# Altium schematic sheet circuit_BLDCControl.SchDoc
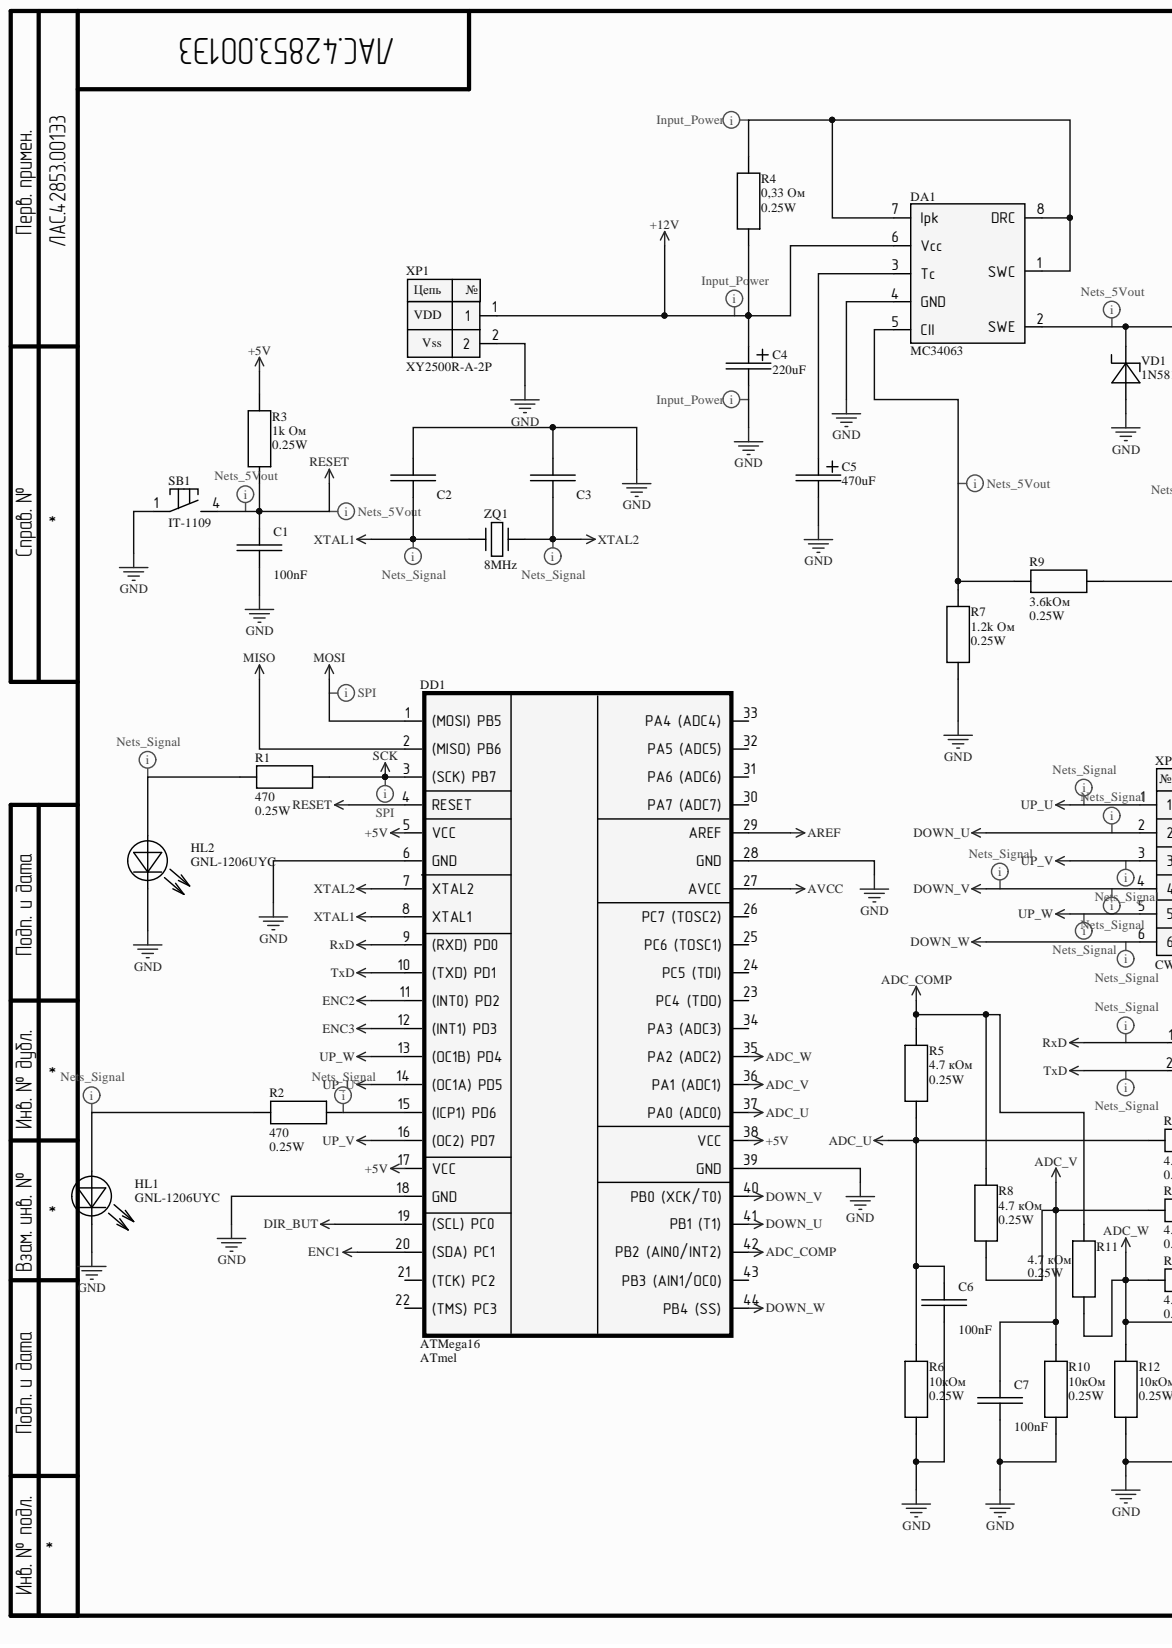
[diagram p0: circuit_BLDCControl.SchDoc, left]
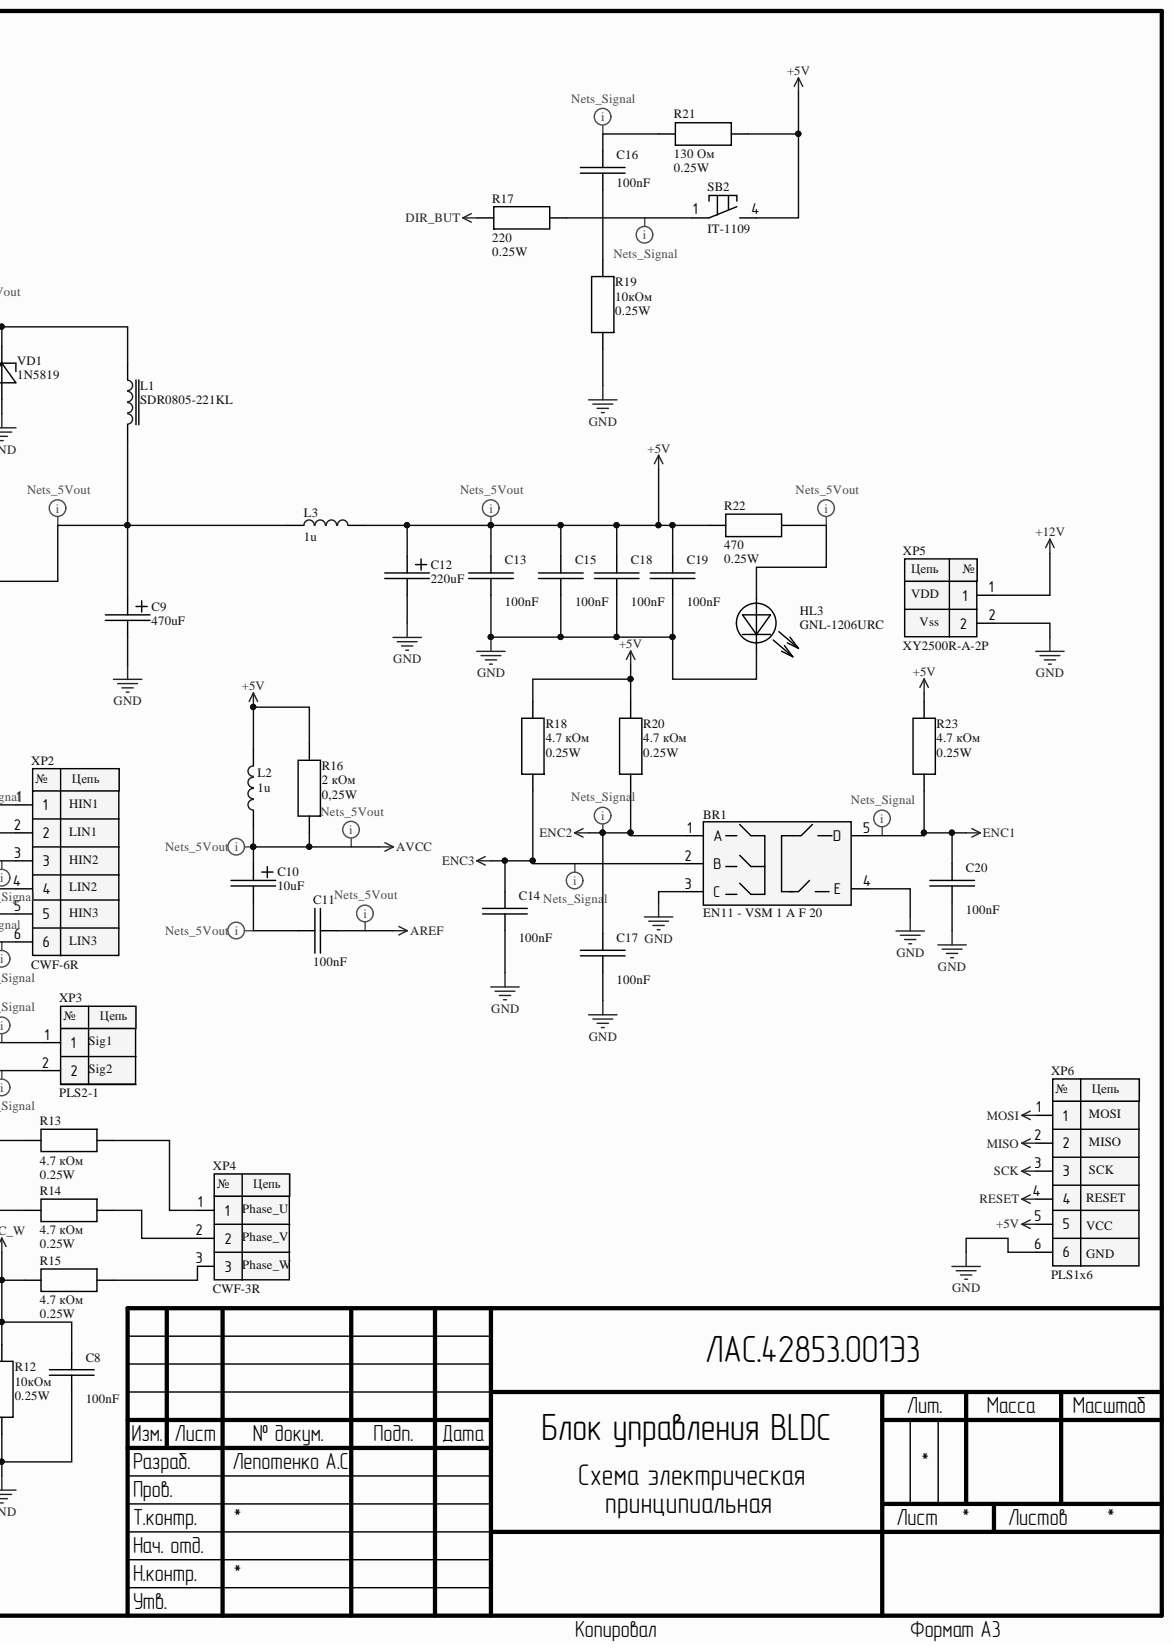
[diagram p1: circuit_BLDCControl.SchDoc, right]

## Parts
BR1  EN11 - VSM 1 A F 20  [EN11-HSM]
C1  100nF  [CAP1206]
C2  22pF  [CAP1206]  (value not drawn)
C3  22pF  [CAP1206]  (value not drawn)
C4  220uF  [CAPAE120103X105MTypeG]
C5  470uF  [CAPAE120103X105MTypeG]
C6  100nF  [CAP1206]
C7  100nF  [CAP1206]
C8  100nF  [CAP1206]
C9  470uF  [CAPAE120103X105MTypeG]
C10  10uF  [Type D]
C11  100nF  [CAP1206]
C12  220uF  [CAPAE120103X105MTypeG]
C13  100nF  [CAP1206]
C14  100nF  [CAP1206]
C15  100nF  [CAP1206]
C16  100nF  [CAP1206]
C17  100nF  [CAP1206]
C18  100nF  [CAP1206]
C19  100nF  [CAP1206]
C20  100nF  [CAP1206]
DA1  MC34063  [SO-8]
DD1  ATMega16  Manufacturer: ATmel  [TQFP80P1200X1200X120-44N]
HL1  GNL-1206UYC  [LED1206]
HL2  GNL-1206UYC  [LED1206]
HL3  GNL-1206URC  [LED1206]
L1  SDR0805-221KL  [SDR0805]
L2  1u  [LQG15HN15NJ]
L3  1u  [LQG15HN15NJ]
R1  470  Power: 0.25W  [1206]
R2  470  Power: 0.25W  [1206]
R3  1k Ом  Power: 0.25W  [1206]
R4  0,33 Ом  Power: 0.25W  [1206]
R5  4.7 кОм  Power: 0.25W  [1206]
R6  10кОм  Power: 0.25W  [1206]
R7  1.2k Ом  Power: 0.25W  [1206]
R8  4.7 кОм  Power: 0.25W  [1206]
R9  3.6kОм  Power: 0.25W  [1206]
R10  10кОм  Power: 0.25W  [1206]
R11  4.7 кОм  Power: 0.25W  [1206]
R12  10кОм  Power: 0.25W  [1206]
R13  4.7 кОм  [1206]
R14  4.7 кОм  Power: 0.25W  [1206]
R15  4.7 кОм  Power: 0.25W  [1206]
R16  2 кОм  Power: 0,25W  [1206]
R17  220  Power: 0.25W  [1206]
R18  4.7 кОм  Power: 0.25W  [1206]
R19  10кОм  Power: 0.25W  [1206]
R20  4.7 кОм  Power: 0.25W  [1206]
R21  130 Ом  [1206]
R22  470  Power: 0.25W  [1206]
R23  4.7 кОм  Power: 0.25W  [1206]
SB1  IT-1109  [KLS2]
SB2  IT-1109  [KLS2]
VD1  1N5819  [DO-41-V]
XP1  XY2500R-A-2P  [KLS2-EDR-500-2P]
XP2  CWF-6R  [CWF-6R]
XP3  PLS2-1  [PLS-2-1]
XP4  CWF-3R  [CWF-3R]
XP5  XY2500R-A-2P  [KLS2-EDR-500-2P]
XP6  PLS1x6  [PLS1x6]
ZQ1  8MHz  [HC49-S]

## Nets
+5V: C12.1 C13.1 C15.1 C18.1 C19.1 DD1.5(VCC) DD1.17(VCC) DD1.38(VCC) L2.2 L3.2 R3.2 R16.2 R18.2 R20.2 R21.2 R22.2 R23.2 SB2.4 XP6.5
+12V: C4.1 DA1.6(Vcc) R4.1 XP1.1 XP5.1
ADC_COMP: DD1.42((AIN0/INT2)) R5.1 R8.1 R11.1
ADC_U: C6.1 DD1.37((ADC0)) R5.2 R6.1 R13.2
ADC_V: C7.1 DD1.36((ADC1)) R8.2 R10.1 R14.2
ADC_W: C8.1 DD1.35((ADC2)) R11.2 R12.1 R15.2
AREF: C11.2 DD1.29(AREF)
AVCC: C10.1 DD1.27(AVCC) L2.1 R16.1
DIR_BUT: DD1.19((SCL)) R17.1
DOWN_U: DD1.41((T1)) XP2.2
DOWN_V: DD1.40((XCK/T0)) XP2.4
DOWN_W: DD1.44((SS)) XP2.6
ENC1: BR1.5(D) C20.1 DD1.20((SDA)) R23.1
ENC2: BR1.1 C17.1 DD1.11((INT0)) R20.1
ENC3: BR1.2(B) C14.1 DD1.12((INT1)) R18.1
GND: BR1.3(C) BR1.4(E) C1.2 C2.1 C3.1 C4.2 C5.2 C6.2 C7.2 C8.2 C9.2 C12.2 C13.2 C14.2 C15.2 C17.2 C18.2 C19.2 C20.2 DA1.4(GND) DD1.6(GND) DD1.18(GND) DD1.28(GND) DD1.39(GND) HL1.2 HL2.2 HL3.2 R6.2 R7.1 R10.2 R12.2 R19.1 SB1.1 VD1.1 XP1.2 XP5.2 XP6.6
MISO: DD1.2((MISO)) XP6.2
MOSI: DD1.1((MOSI)) XP6.1
RESET: C1.1 DD1.4(RESET) R3.1 SB1.4 XP6.4
RxD: DD1.9((RXD)) XP3.1
SCK: DD1.3((SCK)) R1.1 XP6.3
TxD: DD1.10((TXD)) XP3.2
UP_U: DD1.14((OC1A)) XP2.1
UP_V: DD1.16((OC2)) XP2.3
UP_W: DD1.13((OC1B)) XP2.5
XTAL1: C2.2 DD1.8(XTAL1) ZQ1.1
XTAL2: C3.2 DD1.7(XTAL2) ZQ1.3
NetC5_1: C5.1 DA1.3(Tc)
NetC9_1: C9.1 L1.2 L3.1 R9.2
NetC10_2: C10.2 C11.1
NetC16_1: C16.1 R21.1
NetC16_2: C16.2 R19.2
NetDA1_1: DA1.1(SWC) DA1.7(Ipk) DA1.8(DRC) R4.2
NetDA1_2: DA1.2(SWE) L1.1 VD1.2
NetDA1_5: DA1.5(CII) R7.2 R9.1
NetDD1_15: DD1.15((ICP1)) R2.1
NetHL1_1: HL1.1 R2.2
NetHL2_1: HL2.1 R1.2
NetHL3_1: HL3.1 R22.1
NetR13_1: R13.1 XP4.1
NetR14_1: R14.1 XP4.2
NetR15_1: R15.1 XP4.3
NetR17_2: R17.2 SB2.1

Not connected: DD1 21((TCK)) 22((TMS)) 23((TDO)) 24((TDI)) 25((TOSC1)) 26((TOSC2)) 30((ADC7)) 31((ADC6)) 32((ADC5)) 33((ADC4)) 34((ADC3)) 43((AIN1/OC0))

## Library data of the parts
BR1 = Encoder: Manufacturer: TTelectronics; Component Kind: Standard; Documentation: http://belchip.by/sitedocs/18676.pdf; Package: CWF-3R; Part Number: EN11 - VSM 1 A F 20; Price: 0.05; Quantity: 3; ShoppingPlace: http://belchip.by/product/?selected_product=17773; Library Name: SchlibUGO.SchLib
C1 C6-C8 C11 C13-C20 = Capasitor: Manufacturer: Samsung; Part Number: CL31B104KBCNNNC; Voltage: 50V; Component Kind: Standard; Package: 1206; Price: 0,035; Quantity: 4; ShoppingPlace: http://belchip.by/product/?selected_product=31093; Value: 100nF; Library Name: SchlibUGO.SchLib
C2 C3 = Capasitor: Manufacturer: Samsung; Part Number: NPO-50V; Voltage: 50V; Component Kind: Standard; Package: 1206; Price: 0.025; Quantity: 2; ShoppingPlace: http://belchip.by/product/?selected_product=00259; Value: 22pF; Library Name: SchlibUGO.SchLib
C4 C12 = Capasitor Electrolit: Manufacturer: Китай; Part Number: 220uF 35V 10x10; Power: 10V; Component Kind: Standard; Documentation: http://belchip.by/product/?selected_product=32976; Package: 10x10; Price: 1; Quantity: 3; ShoppingPlace: http://belchip.by/product/?selected_product=32976; Value: 220uF; Library Name: SchlibUGO.SchLib
C5 C9 = Capasitor Electrolit: Manufacturer: EPCOS; Part Number: B41121A3477M000; Power: 25V; Component Kind: Standard; Documentation: https://static.chipdip.ru/lib/051/DOC000051935.pdf; Package: 8x10; Price: 2; ShoppingPlace: https://www.ru-chipdip.by/product/b41121a3477m000; Value: 470uF; Library Name: SchlibUGO.SchLib
C10 = Capasitor Electrolit: Manufacturer: Vishay; Part Number: D 10uF 25V 20; Power: 25V; Component Kind: Standard; Package: TypeD; Price: 0,23; ShoppingPlace: http://belchip.by/product/?selected_product=00096; Value: 10uF; Library Name: SchlibUGO.SchLib
DA1 = MC34063: Manufacturer: ON Semiconductor; Component Kind: Standard; Package: SO-8; part Number: MC34063; Price: 0,3; Quantity: 1; ShoppingPlace: http://belchip.by/product/?selected_product=28823; Library Name: SchlibUGO.SchLib
DD1 = ATMega 16: Component Kind: Standard; Documentation: http://belchip.by/sitedocs/00002095.pdf; Package: TQFP44; Part Number: ATMega16; Price: 5,2; Quantity: 1; ShoppingPlace: http://belchip.by/product/?selected_product=02095; Value: ATMega16; Library Name: SchlibUGO.SchLib
HL1 HL2 = LED: Manufacturer: G-NOR; Value: YELLOW; Component Kind: Standard; Package: 1206; Part Number: GNL-1206UYC; Price: 0.06; Quantity: 6; ShoppingPlace: http://belchip.by/product/?selected_product=00949; Library Name: SchlibUGO.SchLib
HL3 = LED: Manufacturer: G-NOR; Value: RED; Component Kind: Standard; Package: 1206; Part Number: GNL-1206URC; Price: 0.06; Quantity: 6; ShoppingPlace: http://belchip.by/product/?selected_product=00953; Library Name: SchlibUGO.SchLib
L1 = Induc_SD1105: Manufacturer: BOURNS; Value: 220uH; Component Kind: Standard; Documentation: http://docs-europe.electrocomponents.com/webdocs/150d/0900766b8150ddf1.pdf; Package: SDR0805; Part Number: SDR0805-221KL; Price: 1; Quantity: 1; ShoppingPlace: https://www.ru-chipdip.by/product/sdr1105-201k; Library Name: SchlibUGO.SchLib
L2 L3 = Inductor: Manufacturer: Bourns; Part Number: CM453232-1R0KL; Component Kind: Standard; Package: 1812; Price: 0.225; Quantity: 2; ShoppingPlace: https://www.ru-chipdip.by/product/cm453232-1r0kl; Value: 1u; Library Name: SchlibUGO.SchLib
R1 R2 R22 = Resistor: Manufacturer: YAGEO; Part Number: RC1206JR-07470RL; Quntity: 3; Component Kind: Standard; Package: 1206; Price: 0.15; ShoppingPlace: http://belchip.by/product/?selected_product=32449; Value: 470; Library Name: SchlibUGO.SchLib
R3 = Resistor: Manufacturer: Royal Ohm; Part Number: RC1206FR-071KL; Quntity: 1; Component Kind: Standard; Package: 1206; Price: 0,02; ShoppingPlace: http://belchip.by/product/?selected_product=19295; Value: 1k Ом; Library Name: SchlibUGO.SchLib
R4 = Resistor: Manufacturer: Royal Ohm; Part Number: RC1206FR-12060R33; Quntity: 1; Component Kind: Standard; Documentation: http://belchip.by/sitedocs/18520.pdf; Package: 1206; Price: 0,5; ShoppingPlace: http://belchip.by/product/?selected_product=32979; Value: 0,33 Ом; Library Name: SchlibUGO.SchLib
R5 R6 R8 R10-R12 R14 R15 R18 R20 R23 = Resistor: Manufacturer: YAGEO; Part Number: RC1206JR-074K7L; Quntity: 4; Component Kind: Standard; Package: 1206; Price: 0,15; ShoppingPlace: http://belchip.by/product/?selected_product=01399; Library Name: SchlibUGO.SchLib
  R5 R8 R11 R14 R15 R18 R20 R23: Value: 4.7 кОм
  R6 R10 R12: Value: 10кОм
R7 = Resistor: Manufacturer: РФ; Part Number: 1206 1.2K; Quntity: 1; Component Kind: Standard; Package: 1206; Price: 0,15; ShoppingPlace: http://belchip.by/product/?selected_product=01386; Value: 1.2k Ом; Library Name: SchlibUGO.SchLib
R9 = Resistor: Manufacturer: РФ; Part Number: 1206 3.6K; Quntity: 1; Component Kind: Standard; Package: 1206; Price: 1; ShoppingPlace: http://belchip.by/product/?selected_product=01396; Value: 3.6kОм; Library Name: SchlibUGO.SchLib
R13 = Resistor: Manufacturer: YAGEO; Part Number: RC1206JR-074K7L; Power: 0.25W; Quntity: 4; Component Kind: Standard; Package: 1206; Price: 0,15; ShoppingPlace: http://belchip.by/product/?selected_product=01399; Value: 4.7 кОм; Library Name: SchlibUGO.SchLib
R16 = Resistor: Manufacturer: РФ; Part Number: SMD 1206 2K; Quntity: 1; Component Kind: Standard; Package: 1206; Price: 0,15; ShoppingPlace: http://belchip.by/product/?selected_product=01391; Value: 2 кОм; Library Name: SchlibUGO.SchLib
R17 = Resistor: Manufacturer: Royal Ohm; Part Number: HP06-220R5; Quntity: 1; Component Kind: Standard; Package: 1206; Price: 0,02; ShoppingPlace: http://belchip.by/product/?selected_product=06325; Value: 220; Library Name: SchlibUGO.SchLib
R19 = Resistor: Manufacturer: YAGEO; Part Number: RC1206JR-0710KL; Quntity: 1; Component Kind: Standard; Package: 1206; Price: 0.15; ShoppingPlace: http://belchip.by/product/?selected_product=31101; Value: 10кОм; Library Name: SchlibUGO.SchLib
R21 = Resistor: Manufacturer: RF; Part Number: 130R; Power: 0.25W; Quntity: 1; Component Kind: Standard; Package: 1206; Price: 0,15; ShoppingPlace: http://belchip.by/product/?selected_product=01363; Value: 130 Ом; Library Name: SchlibUGO.SchLib
SB1 SB2 = Button: Manufacturer: RUICHI; Component Kind: Standard; Package: 4,5x4,5x3,8; Part Number: IT-1109; Price: 0,1; Quantity: 2; ShoppingPlace: http://belchip.by/product/?selected_product=03267; Library Name: SchlibUGO.SchLib
VD1 = 1N5819: Manufacturer: GALAXY; Power: 1A; Value: 40V; Component Kind: Standard; Library Name: Diode_Library.IntLib; Package: SOD-123; Part Number: 1N5819; Price: 0.12; Quantity: 3; ShoppingPlace: http://belchip.by/product/?selected_product=08853
XP1 XP5 = Connector_Power: Manufacturer: РБ; Value: 1000V; Component Kind: Standard; Package: XY2500R-A-2P; Part Number: XY2500R-A-2P; Price: 0,10; Quantity: 2; ShoppingPlace: http://belchip.by/product/?selected_product=14514; Library Name: SchlibUGO.SchLib
XP2 = CWF-6R: Manufacturer: KLS; Component Kind: Standard; Part Number: CWF-6R; Price: 1; Quantity: 1; shoppingPlace: http://belchip.by/product/?selected_product=32444; Library Name: SchlibUGO.SchLib
XP3 = PLS2-1: Manufacturer: KLS; Component Kind: Standard; Package: PLS2-1; Part Number: PLS2-1; Price: 0.05; Quantity: 3; ShoppingPlace: http://belchip.by/product/?selected_product=31993; Library Name: SchlibUGO.SchLib
XP4 = CWF-3R: Manufacturer: KLS; Component Kind: Standard; Package: CWF-3R; Part Number: CWF-3R; Price: 0.05; Quantity: 3; ShoppingPlace: http://belchip.by/product/?selected_product=32444; Library Name: SchlibUGO.SchLib
XP6 = PLS1x6: Manufacturer: KLS; Part Number: KLS1x6; Component Kind: Standard; Price: 1; Quantity: 1; shoppingPlace: http://belchip.by/product/?selected_product=02010; Library Name: SchlibUGO.SchLib
ZQ1 = Rzanator: Manufacturer: CHina; Part Number: HC49US-FF5F8.0000; Component Kind: Standard; Package: HC-51; Price: 0,37; Quantity: 1; ShoppingPlace: http://belchip.by/product/?selected_product=04623; Value: 8MHz; Library Name: SchlibUGO.SchLib
